# Altium schematic sheet merci_proc.SchDoc(merci_proc)
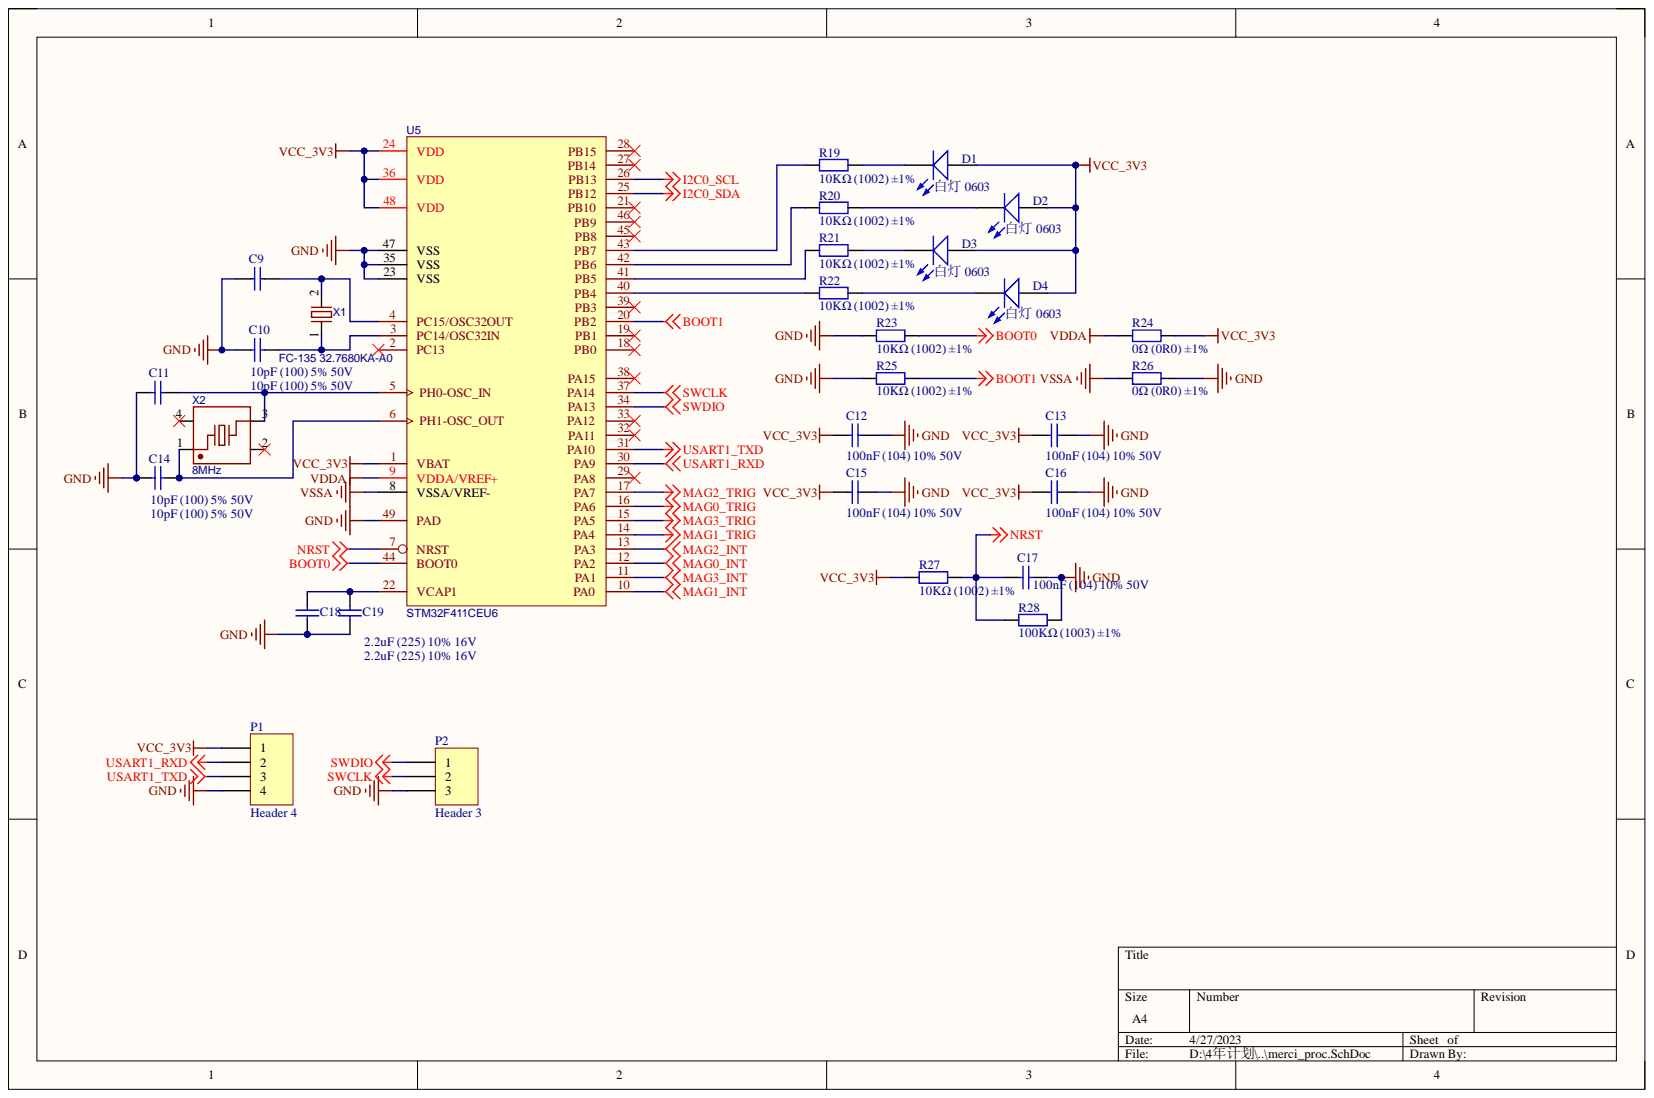
[diagram p0: merci_proc.SchDoc]

## Parts
C9  10pF (100) 5% 50V  [LC-0603_C]
C10  10pF (100) 5% 50V  [LC-0603_C]
C11  10pF (100) 5% 50V  [LC-0603_C]
C12  100nF (104) 10% 50V  [LC-0603_C]
C13  100nF (104) 10% 50V  [LC-0603_C]
C14  10pF (100) 5% 50V  [LC-0603_C]
C15  100nF (104) 10% 50V  [LC-0603_C]
C16  100nF (104) 10% 50V  [LC-0603_C]
C17  100nF (104) 10% 50V  [LC-0603_C]
C18  2.2uF (225) 10% 16V  [LC-0603_C]
C19  2.2uF (225) 10% 16V  [LC-0603_C]
D1  白灯 0603  Package: 0603  [LC-0603_LED_S1]
D2  白灯 0603  Package: 0603  [LC-0603_LED_S1]
D3  白灯 0603  Package: 0603  [LC-0603_LED_S1]
D4  白灯 0603  Package: 0603  [LC-0603_LED_S1]
P1  Header 4  [HDR1X4]
P2  Header 3  [HDR1X3]
R19  10KΩ (1002) ±1%  [LC-0603_R]
R20  10KΩ (1002) ±1%  [LC-0603_R]
R21  10KΩ (1002) ±1%  [LC-0603_R]
R22  10KΩ (1002) ±1%  [LC-0603_R]
R23  10KΩ (1002) ±1%  [LC-0603_R]
R24  0Ω (0R0) ±1%  [LC-0603_R]
R25  10KΩ (1002) ±1%  [LC-0603_R]
R26  0Ω (0R0) ±1%  [LC-0603_R]
R27  10KΩ (1002) ±1%  [LC-0603_R]
R28  100KΩ (1003) ±1%  [LC-0603_R]
U5  STM32F411CEU6  [UFQFPN-48_L7.0-W7.0-P0.50-BL-EP - duplicate]
X1  FC-135 32.7680KA-A0  [CRYSTAL-SMD_L3.2-W1.5-FC-135R32.7680KF-A3]
X2  8MHz  [CRYSTAL-SMD_4P-L3.2-W2.5-BL]

## Nets
BOOT0: R23.2 U5.44(BOOT0)
BOOT1: R25.2 U5.20(PB2)
GND: C9.1 C10.1 C11.1 C12.2 C13.2 C14.1 C15.2 C16.2 C17.2 C18.1 C19.1 P1.4 P2.3 R23.1 R25.1 R26.2 R28.2 U5.23(VSS) U5.35(VSS) U5.47(VSS) U5.49(PAD)
I2C0_SCL: U5.26(PB13)
I2C0_SDA: U5.25(PB12)
MAG0_INT: U5.12(PA2)
MAG0_TRIG: U5.16(PA6)
MAG1_INT: U5.10(PA0)
MAG1_TRIG: U5.14(PA4)
MAG2_INT: U5.13(PA3)
MAG2_TRIG: U5.17(PA7)
MAG3_INT: U5.11(PA1)
MAG3_TRIG: U5.15(PA5)
NRST: C17.1 R27.2 R28.1 U5.7(NRST)
SWCLK: P2.2 U5.37(PA14)
SWDIO: P2.1 U5.34(PA13)
USART1_RXD: P1.2 U5.30(PA9)
USART1_TXD: P1.3 U5.31(PA10)
VCC_3V3: C12.1 C13.1 C15.1 C16.1 D1.A D2.A D3.A D4.A P1.1 R24.2 R27.1 U5.1(VBAT) U5.24(VDD) U5.36(VDD) U5.48(VDD)
VDDA: R24.1 U5.9(VDDA/VREF+)
VSSA: R26.1 U5.8(VSSA/VREF-)
NetC9_2: C9.2 U5.4(PC15/OSC32OUT) X1.2
NetC10_2: C10.2 U5.3(PC14/OSC32IN) X1.1
NetC11_2: C11.2 U5.5(PH0-OSC_IN) X2.3
NetC14_2: C14.2 U5.6(PH1-OSC_OUT) X2.1
NetC18_2: C18.2 C19.2 U5.22(VCAP1)
NetD1_K: D1.K R19.2
NetD2_K: D2.K R20.2
NetD3_K: D3.K R21.2
NetD4_K: D4.K R22.2
NetR19_1: R19.1 U5.43(PB7)
NetR20_1: R20.1 U5.42(PB6)
NetR21_1: R21.1 U5.41(PB5)
NetR22_1: R22.1 U5.40(PB4)

Not connected: U5 2(PC13) 18(PB0) 19(PB1) 21(PB10) 27(PB14) 28(PB15) 29(PA8) 32(PA11) 33(PA12) 38(PA15) 39(PB3) 45(PB8) 46(PB9); X2 2 4

## Library data of the parts
C9-C11 C14 = 0603 10pF (100) 5% 50V: 贴片电容; Component Kind: Standard; ComponentLink1Description: 供应商链接; ComponentLink1URL: http://www.szlcsc.com/product/details_1986.html; Library Name: Miscellaneous Devices LC.IntLib; Package: 0603; Supplier: LC; SuppliersPartNumber: C1634
C12 C13 C15-C17 = 0603 100nF (104) 10% 50V: 贴片电容; Component Kind: Standard; ComponentLink1Description: 供应商链接; ComponentLink1URL: http://www.szlcsc.com/product/details_15331.html; Library Name: Miscellaneous Devices LC.IntLib; Package: 0603; Supplier: LC; SuppliersPartNumber: C14663
C18 C19 = 0603 2.2uF (225) 10% 16V: 贴片电容; Component Kind: Standard; ComponentLink1Description: 供应商链接; ComponentLink1URL: http://www.szlcsc.com/product/details_24366.html; Library Name: Miscellaneous Devices LC.IntLib; Package: 0603; Supplier: LC; SuppliersPartNumber: C23630
D1-D4 = 0603 白灯: 发光二极管; Component Kind: Standard; ComponentLink1Description: 供应商链接; ComponentLink1URL: http://www.szlcsc.com/product/details_2663.html; Library Name: Miscellaneous Devices LC.IntLib; Supplier: LC; SuppliersPartNumber: C2290
P1 = Header 4: Header, 4-Pin; Component Kind: Standard; LatestRevisionDate: 17-Jul-2002; LatestRevisionNote: Re-released for DXP Platform.; Library Name: Common Headers.SchLib; Publisher: Altium Limited
P2 = Header 3: Header, 3-Pin; Component Kind: Standard; LatestRevisionDate: 17-Jul-2002; LatestRevisionNote: Re-released for DXP Platform.; Library Name: Common Headers.SchLib; Publisher: Altium Limited
R19-R23 R25 R27 = 0603 10KΩ (1002) 1%: 贴片电阻; Component Kind: Standard; ComponentLink1Description: 供应商链接; ComponentLink1URL: http://www.szlcsc.com/product/details_26547.html; Library Name: Miscellaneous Devices LC.IntLib; Package: 0603; Supplier: LC; SuppliersPartNumber: C25804
R24 R26 = 0603 0Ω (0R0) 1%: 贴片电阻; Component Kind: Standard; ComponentLink1Description: 供应商链接; ComponentLink1URL: http://www.szlcsc.com/product/details_21903.html; Library Name: Miscellaneous Devices LC.IntLib; Package: 0603; Supplier: LC; SuppliersPartNumber: C21189
R28 = 0603 100KΩ (1003) 1%: 贴片电阻; Component Kind: Standard; ComponentLink1Description: 供应商链接; ComponentLink1URL: http://www.szlcsc.com/product/details_26546.html; Library Name: Miscellaneous Devices LC.IntLib; Package: 0603; Supplier: LC; SuppliersPartNumber: C25803
U5 = STM32F411CEU6: BOM_Manufacturer: ST(意法半导体); BOM_JLCPCB Part Class: Extended Part; BOM_Manufacturer Part: STM32F411CEU6; BOM_Supplier: LCSC; BOM_Supplier Part: C60420; Component Kind: Standard; spicePre: U; spiceSymbolName: STM32F411CEU6; Library Name: merci.SchLib
X1 = FC-135 32.7680KA-A0: BOM_Manufacturer: EPSON(爱普生); Contributor: lcsc; BOM_Manufacturer Part: FC-135 32.7680KA-A0; BOM_Supplier: LCSC; BOM_Supplier Part: C2650444; Component Kind: Standard; link: https://atta.szlcsc.com/upload/public/pdf/source/20211127/C2650444_3DEB46927A758E75F8CF3F6FA0A6BD9B.pdf; spicePre: X; spiceSymbolName: FC-135 32.7680KA-A0; Library Name: merci.SchLib
X2 = 8MHz: BOM_Manufacturer: JWT(晶威特); BOM_Manufacturer Part: CF4008M00012T8188031; Contributor: LCSC; nameAlias: Frequency; BOM_Supplier: LCSC; BOM_Supplier Part: C2933033; Component Kind: Standard; spicePre: X; spiceSymbolName: CF4008M00012T8188031; Library Name: merci.SchLib
